# Altium schematic sheet p06_USB.SchDoc
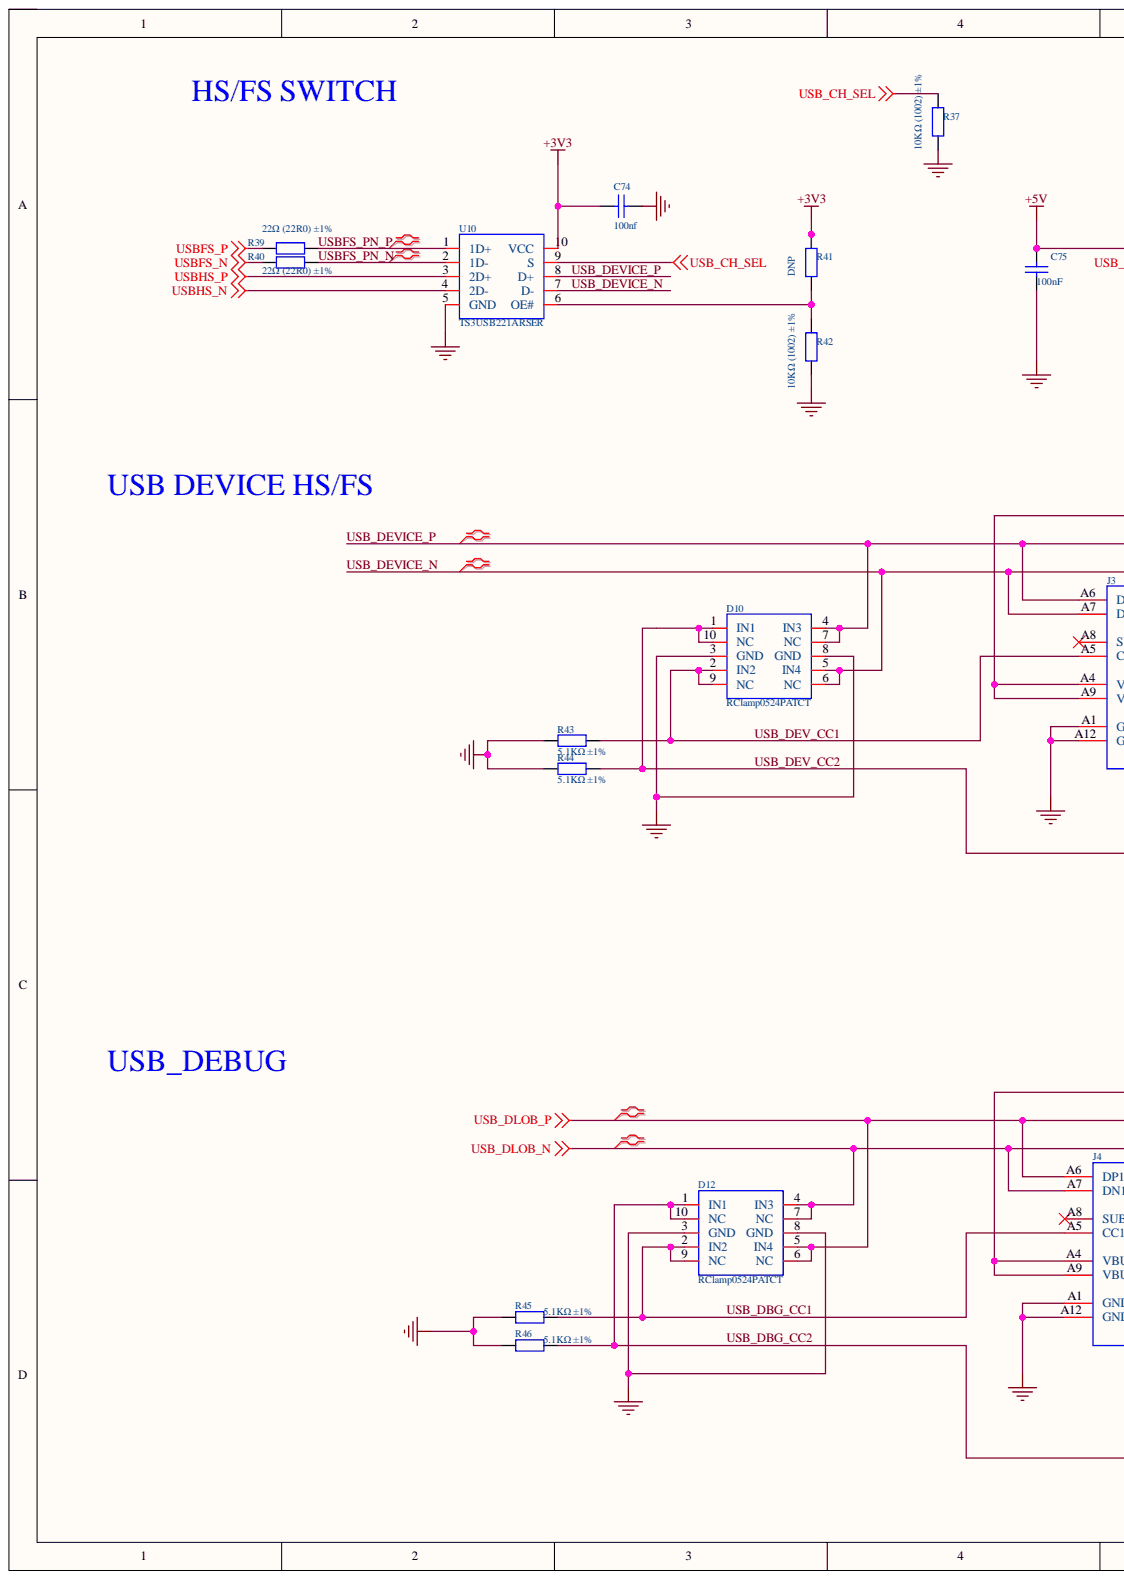
[diagram p0: p06_USB.SchDoc, left]
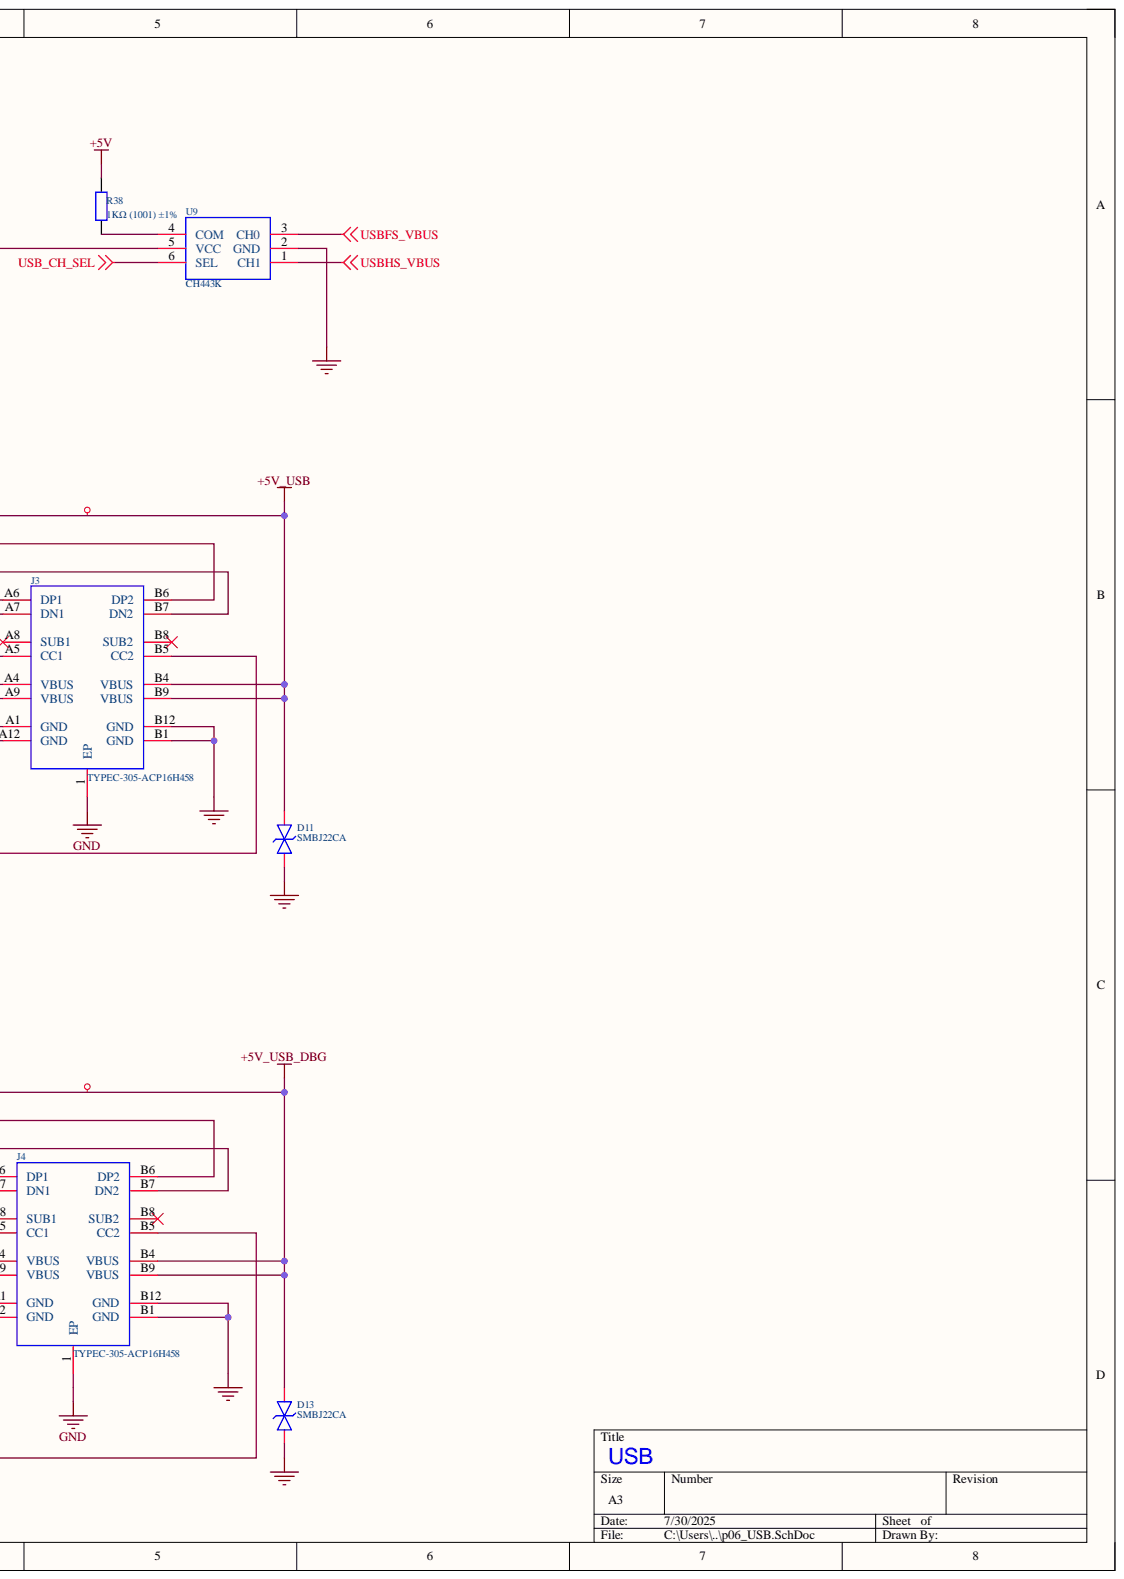
[diagram p1: p06_USB.SchDoc, right]

## Parts
C74  100nf  [C0201]
C75  100nF  [C0402]
D10  RClamp0524PATCT  [SLP2510P8_L2.5-W1.0-P0.50-BL]
D11  SMBJ22CA  [SMB_L4.6-W3.6-LS5.4-BI]
D12  RClamp0524PATCT  [SLP2510P8_L2.5-W1.0-P0.50-BL]
D13  SMBJ22CA  [SMB_L4.6-W3.6-LS5.4-BI]
J3  TYPEC-305-ACP16H458  [USB-C-SMD_TYPEC-305-ACP16H458]
J4  TYPEC-305-ACP16H458  [USB-C-SMD_TYPEC-305-ACP16H458]
R37  10KΩ (1002) ±1%  [R0402]
R38  1KΩ (1001) ±1%  [R0402]
R39  22Ω (22R0) ±1%  [R0402]
R40  22Ω (22R0) ±1%  [R0402]
R41  DNP  [R0402]
R42  10KΩ (1002) ±1%  [R0402]
R43  5.1KΩ ±1%  [R0402]
R44  5.1KΩ ±1%  [R0402]
R45  5.1KΩ ±1%  [R0402]
R46  5.1KΩ ±1%  [R0402]
U9  CH443K  [SC70-6_L2.1-W1.3-P0.65-LS2.3-BL]
U10  TS3USB221ARSER  [UQFN-10_L2.0-W1.5-P0.50-BL]

## Nets
+3V3: C74.1 R41.2 U10.10(VCC)
+5V: C75.1 R38.2 U9.5(VCC)
+5V_USB: D11.2 J3.A4(VBUS) J3.A9(VBUS) J3.B4(VBUS) J3.B9(VBUS)
+5V_USB_DBG: D13.2 J4.A4(VBUS) J4.A9(VBUS) J4.B4(VBUS) J4.B9(VBUS)
GND: C74.2 C75.2 D10.3(GND) D10.8(GND) D11.1 D12.3(GND) D12.8(GND) D13.1 J3.1(EP) J3.A1(GND) J3.A12(GND) J3.B1(GND) J3.B12(GND) J4.1(EP) J4.A1(GND) J4.A12(GND) J4.B1(GND) J4.B12(GND) R37.1 R42.1 R43.2 R44.2 R45.2 R46.2 U9.2(GND) U10.5(GND)
USB_CH_SEL: R37.2 U9.6(SEL) U10.9(S)
USB_DLOB_N: D12.4(IN3) D12.7(NC) J4.A7(DN1) J4.B7(DN2)
USB_DLOB_P: D12.5(IN4) D12.6(NC) J4.A6(DP1) J4.B6(DP2)
USBFS_N: R40.1
USBFS_P: R39.2
USBFS_VBUS: U9.3(CH0)
USBHS_N: U10.4(2D-)
USBHS_P: U10.3(2D+)
USBHS_VBUS: U9.1(CH1)
USB_DBG_CC1: D12.2(IN2) D12.9(NC) J4.A5(CC1) R45.1
USB_DBG_CC2: D12.1(IN1) D12.10(NC) J4.B5(CC2) R46.1
USB_DEV_CC1: D10.2(IN2) D10.9(NC) J3.A5(CC1) R43.1
USB_DEV_CC2: D10.1(IN1) D10.10(NC) J3.B5(CC2) R44.1
USB_DEVICE_N: D10.5(IN4) D10.6(NC) J3.A7(DN1) J3.B7(DN2) U10.7(D-)
USB_DEVICE_P: D10.4(IN3) D10.7(NC) J3.A6(DP1) J3.B6(DP2) U10.8(D+)
USBFS_PN_N: R40.2 U10.2(1D-)
USBFS_PN_P: R39.1 U10.1(1D+)
NetR38_1: R38.1 U9.4(COM)
NetR41_1: R41.1 R42.2 U10.6(OE#)

Not connected: J3 A8(SUB1) B8(SUB2); J4 A8(SUB1) B8(SUB2)

## Library data of the parts
C74 C75 = CAP-000030-01: 0201,100nF ±10% 16V; Legacy Part Number: 151-10004022; Manufacturer: muRata; Manufacturer Part Number 1: CC0402KRX7R8BB104; Dialectric: X7R; Notes: ANY EQUIVALENT; Capacitance: 100nF; Component Kind: Standard; ComponentLink1Description: New link; ComponentLink1URL: https://item.szlcsc.com/698285.html?fromZone=l_c__%2522catalog%2522&spm=sc.cid.xh1.zy.n___sc.gb.xh1.zy.l&lcsc_vid=FgdZAQZURgVfVlRQQQNbBAcFQVZYX1NeQ1BYX10ARFkxVlNSQ1FZUVReQVdaUjsOAxUeFF5JWAgaAglIBBsCBBcFWQIBCks%3D; Library Name: ra8p1_renesas.SCHLIB; Manufacturer 1: muRata; Manufacturer 2: Kemet; Manufacturer 3: AVX; Manufacturer Part Number 2: C0402C104K4RACTU; Manufacturer Part Number 3: 0402YC104KAT2A; Mfg: muRata; Mfg P/N: CC0402KRX7R8BB104; Mfg Part Number: CC0402KRX7R8BB104; PN: CC0402KRX7R8BB104; Supplier 1: Arrow Electronics; Supplier 2: Digi-Key; Supplier 3: Newark; Supplier 4: Mouser; Supplier Part Number 1: CC0402KRX7R8BB104; Supplier Part Number 2: 311-2077-1-ND; Supplier Part Number 3: 40AJ6370; Supplier Part Number 4: 603-CC402KRX7R8BB104; Tolerance: 10%; Voltage: 16V
  C74: Package: 0402
  C75: Package: 0201
D10 D12 = RClamp0524PATCT: ESD; Manufacturer: SEMTECH; Add into BOM: yes; Breakdown Voltage: 6V; Device: RClamp0524PATCT_C40960; Maximum Clamping Voltage: 15V; Number of Lines: 四路; Peak Pulse Power Dissipation (Ppp): 150W; Reverse Stand-Off Voltage (Vrwm): 5V; Component Kind: Standard; Convert to PCB: yes; Datasheet: https://atta.szlcsc.com/upload/public/pdf/source/20150124/1457707260739.pdf; JLCPCB Part Class: Extended Part; LCSC Part Name: RClamp0524PATCT; Library Name: ra8p1_v0.2.SCHLIB; Manufacturer Part: RClamp0524PATCT; Operating Temperature: -55℃~+125℃@(Tj); PCB3D: SLP2510-8P_L2.5-W1.0-H0.5; Supplier: LCSC; Supplier Footprint: DFN-10(1x2.5); Supplier Part: C40960; Symbol: RClamp0524PATCT; Type: ESD
D11 D13 = SMBJ22CA_C19077577: TVS-ESD,22V,16.9A; Manufacturer: 宏嘉诚; Add into BOM: yes; Clamping Voltage: 35.5V; Peak Pulse Power Dissipation (Ppp): 600W; Polarity: 双向; Reverse Leakage Current (Ir): 5uA; Type: TVS; Voltage - Breakdown: 26.9V; Component Kind: Standard; Convert to PCB: yes; Datasheet: https://atta.szlcsc.com/upload/public/pdf/source/20250107/474F05451FC8AB27D7FA0238914F96F6.pdf; Device: SMBJ22CA_C19077577; JLCPCB Part Class: Extended Part; LCSC Part Name: SMBJ22CA; Library Name: ra8p1_v0.1.SCHLIB; Manufacturer Part: SMBJ22CA; Operating Temperature: -; PCB3D: SMB_L4.6-W3.6-LS5.4-BI; Peak Pulse Current (Ipp): 16.9A; Reverse Stand-Off Voltage (Vrwm): 22V; Supplier: LCSC; Supplier Footprint: SMB; Supplier Part: C19077577; Symbol: SMBJ22CA_C19077577
J3 J4 = TYPEC-305-ACP16H458: 侧贴; Manufacturer: kinghelm(金航标); Add into BOM: yes; Connector Type: Type-C; Current Rating - Power (Max): 3A; Device: KH-TYPE-C-16P; Gender: 母; Number of Contacts: 16P; Number of Ports: 1; Component Kind: Standard; Convert to PCB: yes; Datasheet: https://atta.szlcsc.com/upload/public/pdf/source/20200911/C709357_AA8DCEB38BB20E3035A7ED7AE7667837.pdf; JLCPCB Part Class: Extended Part; LCSC Part Name: Type-C 母 卧贴; Library Name: ra8p1_v0.2.SCHLIB; Manufacturer Part: KH-TYPE-C-16P; Mounting Style: 卧贴; Operating Temperature Range: -40℃~+85℃; PCB3D: USB-C-SMD_KH-TYPE-C-16P; Standard: -; Supplier: LCSC; Supplier Footprint: SMD; Supplier Part: C709357; Symbol: KH-TYPE-C-16P
R37 R41 R42 = 0402 10KΩ (1002) 1%: 贴片电阻，10K; Component Kind: Standard; ComponentLink1Description: 供应商链接; ComponentLink1URL: http://www.szlcsc.com/product/details_26487.html; Library Name: RES.SchLib; Package: 0402; Supplier: LC; SuppliersPartNumber: C25744
R38 = 0402 1KΩ (1001) 1%: 贴片电阻; Component Kind: Standard; ComponentLink1Description: 供应商链接; ComponentLink1URL: http://www.szlcsc.com/product/details_12256.html; Library Name: RES.SchLib; Package: 0402; Supplier: LC; SuppliersPartNumber: C11702
R39 R40 = 0402 22Ω (22R0) 1%: 贴片电阻; Component Kind: Standard; ComponentLink1Description: 供应商链接; ComponentLink1URL: http://www.szlcsc.com/product/details_25835.html; Library Name: RES.SchLib; Package: 0402; Supplier: LC; SuppliersPartNumber: C25092
R43-R46 = 0402 10KΩ (1002) 1%: 贴片电阻，5.1K; Component Kind: Standard; ComponentLink1Description: 供应商链接; ComponentLink1URL: https://item.szlcsc.com/26648.html?fromZone=l_b__%2522brand%2522&spm=sc.bid.xh3.zy.n&lcsc_vid=FANcVFBQFQMNX1cFFAdZVVZXEgdeAgdWFlQNUVAHT1ExVlNSRFhXU1VeR1VXUDsOAxUeFF5JWA0HFBBIBBsCBBcFWQIBCksEBQAAA0tfTk8GEwkK; Library Name: RES.SchLib; Package: 0402; Supplier: LC; SuppliersPartNumber: C25744
U9 = CH443K: Manufacturer: WCH(南京沁恒); Add into BOM: yes; Component Kind: Standard; Convert to PCB: yes; Datasheet: https://atta.szlcsc.com/upload/public/pdf/source/20200819/C125185_598679904862E814E7C3CDA2CBF564BC.pdf; Device: CH443K; JLCPCB Part Class: Extended Part; LCSC Part Name: 1单刀双掷5V低阻模拟开关芯片; Library Name: ra8p1_v0.1.SCHLIB; Manufacturer Part: CH443K; PCB3D: SC70-6_L2.1-W1.3-P0.65-LS2.3-BL; Supplier: LCSC; Supplier Footprint: SC-70-6(SOT-363); Supplier Part: C125185; Switch Circuit: -; Symbol: CH443K
U10 = TS3USB221ARSER: 模拟开关，usb专用; Manufacturer: TI(德州仪器); -3dB Bandwidth: 900MHz; Add into BOM: yes; RDS(on): 6Ω; Switch Circuit: 单刀双掷(SPDT); Voltage - Supply: 2.5V~3.3V; Component Kind: Standard; Convert to PCB: yes; Datasheet: https://www.ti.com/cn/lit/gpn/ts3usb221a; Device: TS3USB221ARSER; JLCPCB Part Class: Extended Part; LCSC Part Name: TS3USB221A ESD保护、高速USB2.0 (480-Mbps) 1:2多路复用器和解复用器开关，带单使能; Library Name: ra8p1_v0.2.SCHLIB; Manufacturer Part: TS3USB221ARSER; Operating Temperature: -40℃~+85℃; PCB3D: UQFN-10_L2.0-W1.5-H0.6-P0.50; Supplier: LCSC; Supplier Footprint: UQFN-10(1.5x2); Supplier Part: C128396; Symbol: TS3USB221ARSER
